# Altium schematic sheet display.SchDoc(display)
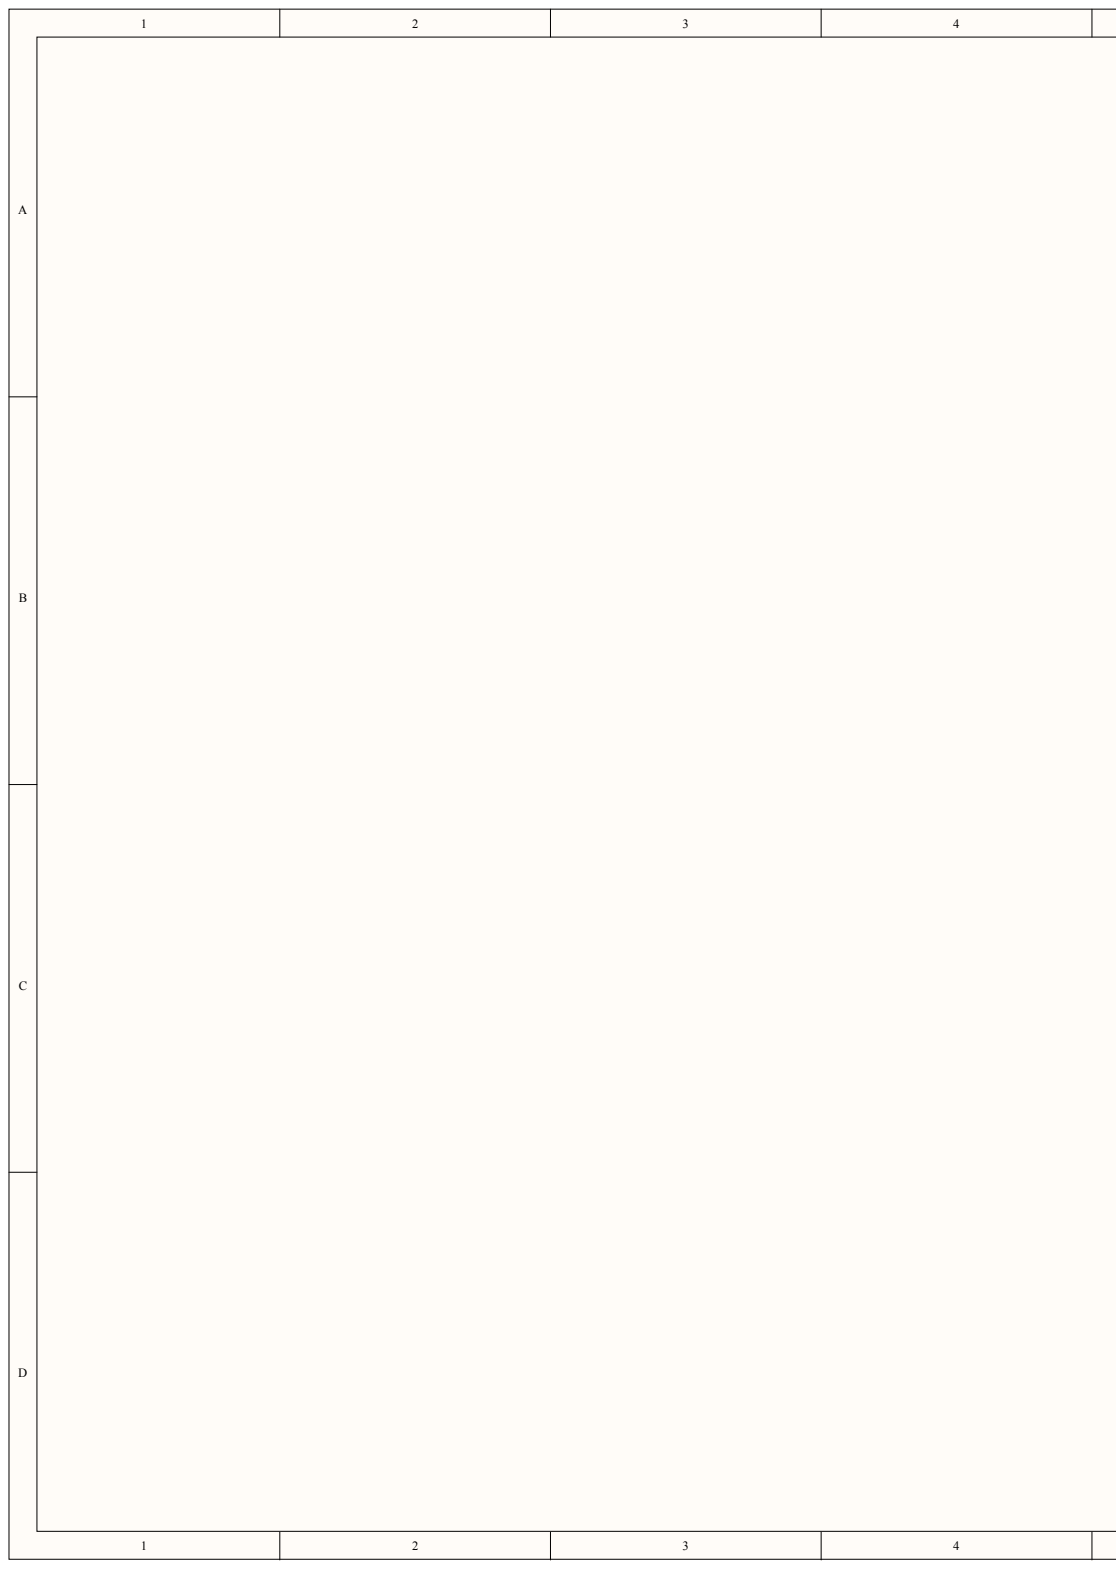
[diagram p0: display.SchDoc, left]
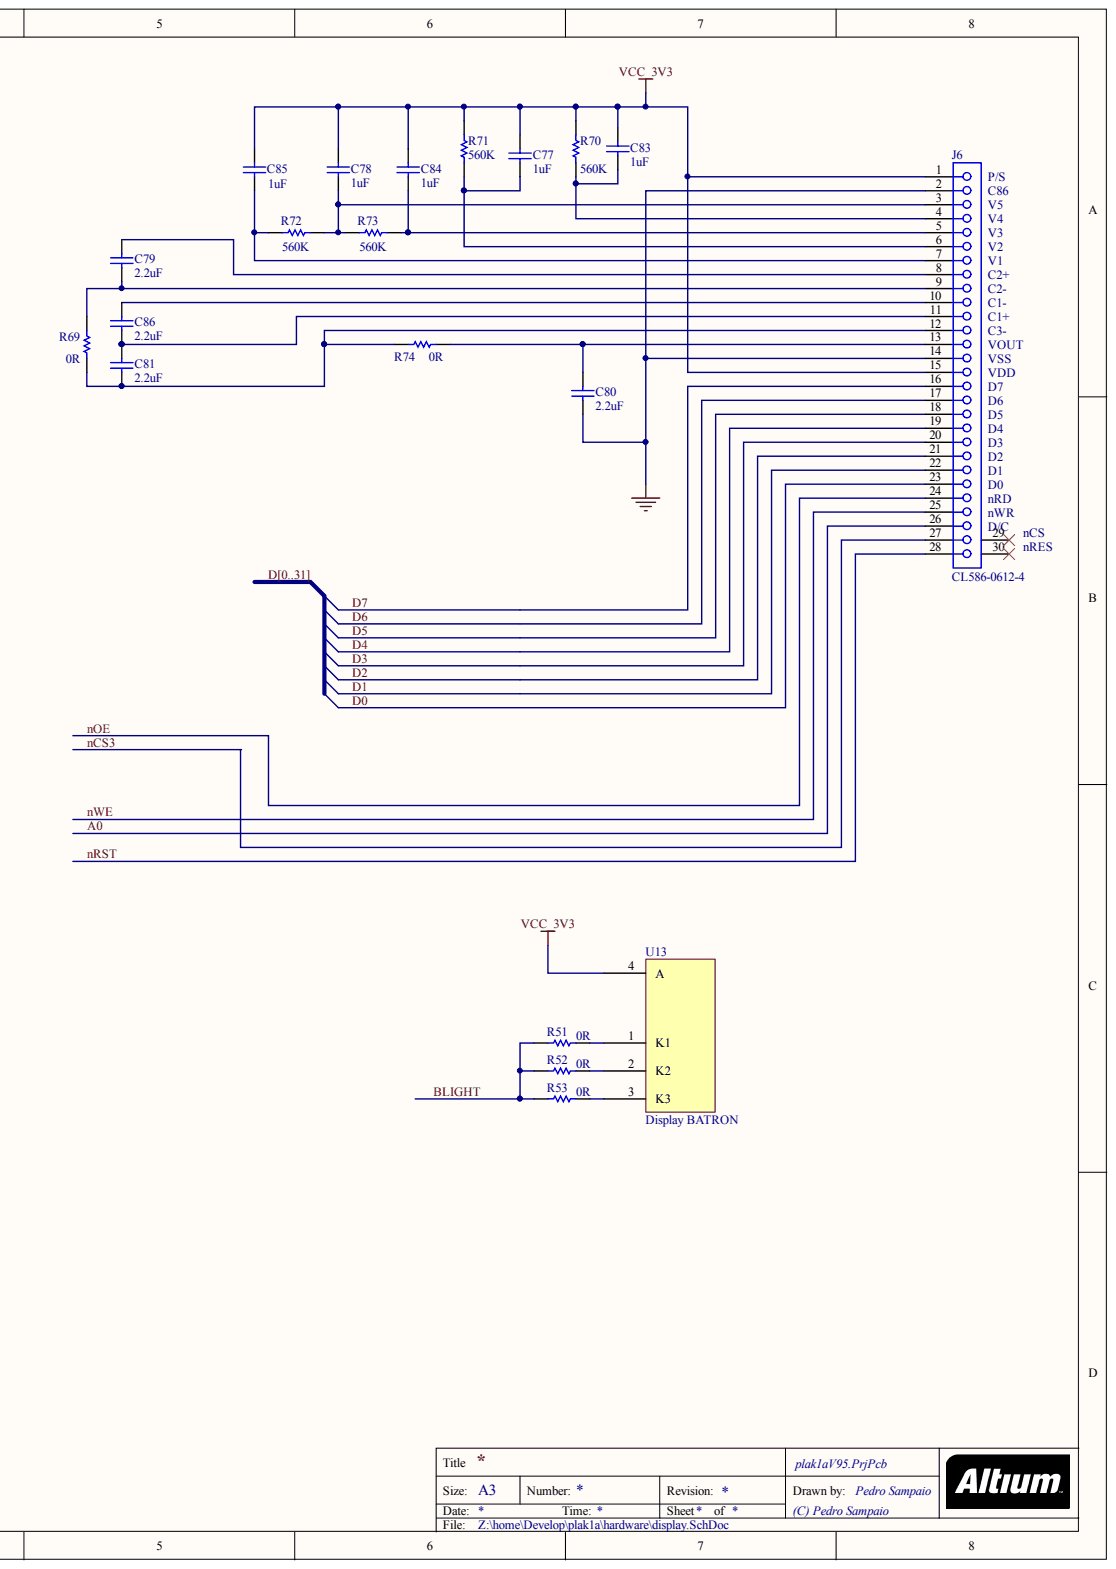
[diagram p1: display.SchDoc, right]

## Parts
C77  value 1uF  [2012[0805]]  (hidden comment: 8805628)
C78  value 1uF  [2012[0805]]  (hidden comment: 8805628)
C79  value 2.2uF  [1608[0603]]  (hidden comment: 9402101)
C80  value 2.2uF  [1608[0603]]  (hidden comment: 9402101)
C81  value 2.2uF  [1608[0603]]  (hidden comment: 9402101)
C83  value 1uF  [2012[0805]]  (hidden comment: 8805628)
C84  value 1uF  [2012[0805]]  (hidden comment: 8805628)
C85  value 1uF  [2012[0805]]  (hidden comment: 8805628)
C86  value 2.2uF  [1608[0603]]  (hidden comment: 9402101)
J6  CL586-0612-4  [FH12-28S-0.5SH]
R51  value 0R  [3216[1206]]  (hidden comment: 9240489)
R52  value 0R  [3216[1206]]  (hidden comment: 9240489)
R53  value 0R  [3216[1206]]  (hidden comment: 9240489)
R69  value 0R  [3216[1206]]  (hidden comment: Res Semi)
R70  value 560K  [3216[1206]]  (hidden comment: 9333711)
R71  value 560K  [3216[1206]]  (hidden comment: 9333711)
R72  value 560K  [3216[1206]]  (hidden comment: 9333711)
R73  value 560K  [3216[1206]]  (hidden comment: 9333711)
R74  value 0R  [3216[1206]]  (hidden comment: Res Semi)
U13  Display BATRON  [BTHQ128064AVD-COG-FSTF-LEDMULTI]

## Nets
GND: C80.1 J6.2 J6.14
VCC_3V3: C77.2 C78.2 C83.2 C84.2 C85.2 J6.1 J6.15 R70.2 R71.2 U13.4(A)
A0: J6.26
BLIGHT: R51.1 R52.1 R53.1
D0: J6.23  [also labelled D]
D1: J6.22  [also labelled D]
D2: J6.21  [also labelled D]
D3: J6.20  [also labelled D]
D4: J6.19  [also labelled D]
D5: J6.18  [also labelled D]
D6: J6.17  [also labelled D]
D7: J6.16  [also labelled D]
NCS3: J6.27
NOE: J6.24
NRST: J6.28
NWE: J6.25
NetC77_1: C77.1 J6.6 R71.1
NetC78_1: C78.1 J6.3 R72.2 R73.1
NetC79_1: C79.1 J6.9 R69.2
NetC79_2: C79.2 J6.8
NetC80_2: C80.2 J6.13 R74.2
NetC81_1: C81.1 J6.12 R69.1 R74.1
NetC81_2: C81.2 C86.1 J6.11
NetC83_1: C83.1 J6.4 R70.1
NetC84_1: C84.1 J6.5 R73.2
NetC85_1: C85.1 J6.7 R72.1
NetC86_2: C86.2 J6.10
NetR51_2: R51.2 U13.1(K1)
NetR52_2: R52.2 U13.2(K2)
NetR53_2: R53.2 U13.3(K3)
D8:  [also labelled D]
D9:  [also labelled D]
D10:  [also labelled D]
D11:  [also labelled D]
D12:  [also labelled D]
D13:  [also labelled D]
D14:  [also labelled D]
D15:  [also labelled D]
D16:  [also labelled D]
D17:  [also labelled D]
D18:  [also labelled D]
D19:  [also labelled D]
D20:  [also labelled D]
D21:  [also labelled D]
D22:  [also labelled D]
D23:  [also labelled D]
D24:  [also labelled D]
D25:  [also labelled D]
D26:  [also labelled D]
D27:  [also labelled D]
D28:  [also labelled D]
D29:  [also labelled D]
D30:  [also labelled D]
D31:  [also labelled D]

Not connected: J6 29 30

## Library data of the parts
C77 C78 C83-C85 = C0805: Ceramic Chip Capacitor - Standard; Note: Footprint corresponds to dimensions for bulk cassette packaging. This case size has tightened tolerances.; Code_IPC: 2012[0805]; Code_JEITA: 0805; Component Kind: Standard; ComponentLink1Description: Manufacturer Link; ComponentLink1URL: http://www.kemet.com/; ComponentLink2Description: Datasheet; ComponentLink2URL: http://www.kemet.com/kemet/web/homepage/kechome.nsf/vapubfilesname/F3102TA.pdf/$file/F3102TA.pdf; DatasheetDocument: Mar-2001; Farnell: 8805628; LatestRevisionDate: 19-Mar-2004; LatestRevisionNote: Silkscreen size reduced.; Library Name: KEMET Chip Capacitor.IntLib; PackageDocument: Mar-2001; PackageReference: 0805; Published: 17-Jul-2002; Signal Integrity: C0805; Simulation: CAP; Publisher: Altium Limited
C79-C81 C86 = C0603: Ceramic Chip Capacitor - Standard; Note: Footprint corresponds to dimensions for bulk cassette packaging. This case size has tightened tolerances.; Code_IPC: 1608 [0603]; Code_JEITA: 0603; Component Kind: Standard; ComponentLink1Description: Manufacturer Link; ComponentLink1URL: http://www.kemet.com/; ComponentLink2Description: Datasheet; ComponentLink2URL: http://www.kemet.com/kemet/web/homepage/kechome.nsf/vapubfilesname/F3102TA.pdf/$file/F3102TA.pdf; DatasheetDocument: Mar-2001; Farnell: 1327728; LatestRevisionDate: 19-Mar-2004; LatestRevisionNote: Silkscreen size reduced.; Library Name: KEMET Chip Capacitor.IntLib; PackageDocument: Mar-2001; PackageReference: 0603; Published: 17-Jul-2002; Signal Integrity: C0603; Simulation: CAP; Publisher: Altium Limited
J6 = CL586-0612-4: FH12 Series, "Flip-Lock" SMT/ZIF Connector - 0.5mm Spaced Bottom Contact; ContactMaterial: Phosphor Bronze; Current: 0.4A; PCB_Layout: Complies with manufacturer's recommendation.; Positions: 30; Component Kind: Standard; ComponentLink1Description: Manufacturer Link; ComponentLink1URL: http://www.hiroseusa.com/; ComponentLink2Description: Datasheet; ComponentLink2URL: http://www.hirose.co.jp/cataloge_hp/e58605370.pdf; DatasheetDocument: 13-Nov-2000; Farnell: 1324554; Library Name: Componentes.IntLib; PackageDocument: 13-Nov-2000; PackageReference: FH12-30S-0.5SH; PadDimensions: Follow nominal values, Suitable for Wave Soldering, Adjust for Re-flow.; Published: 17-Jul-2002; Signal Integrity: FH12; Publisher: Altium Limited
R51-R53 R69-R74 = Res Semi: Semiconductor Resistor; Component Kind: Standard; LatestRevisionDate: 17-Jul-2002; LatestRevisionNote: Re-released for DXP Platform.; Library Name: Miscellaneous Devices.IntLib; PackageReference: AXIAL-0.5; Published: 8-Jun-2000; Signal Integrity: Res Semi; Simulation: RES; Publisher: Altium Limited
  R51-R53 R69 R74: Farnell: 9240489
  R70-R73: Farnell: 9333711
U13 = BTHQ128064AVD-COG-FSTF-LEDMULTI: Component Kind: Standard; Library Name: Componentes.IntLib
